# Altium schematic sheet ESP8285.SchDoc
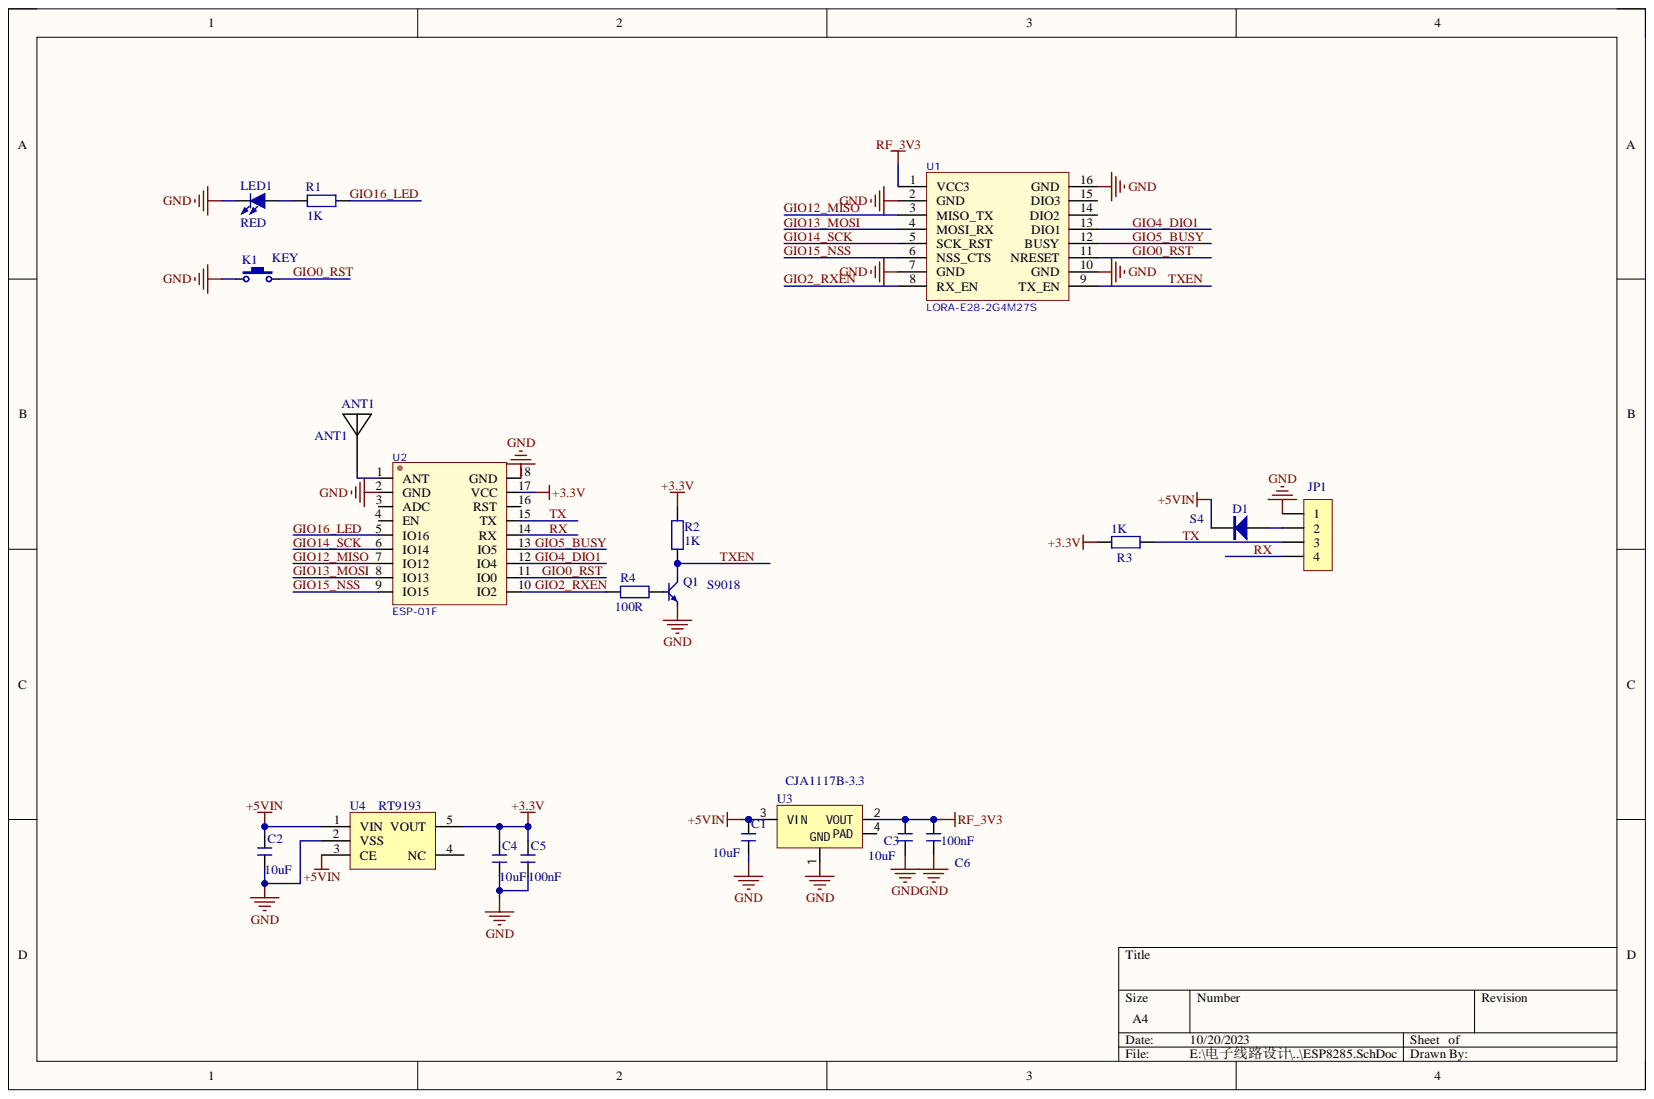
[diagram p0: ESP8285.SchDoc]

## Parts
ANT1  ANT1  [ANT_2.4G]
C1  10uF  [CAP_0603]
C2  10uF  [CAP_0603]
C3  10uF  [CAP_0603]
C4  10uF  [CAP_0603]
C5  100nF  [CAP_0603]
C6  100nF  [CAP_0603]
D1  S4  [SOD-123]
JP1  HDR-1X4  [HDR1X4_2.54_180]  (value not drawn)
K1  KEY  [SPARKFUN-JUMPERS_SMT-JUMPER_2_NC_TRACE_SILK]
LED1  RED  [LED_0603_RED]
Q1  S9018  [SOT23-3]
R1  1K  [RES_0603]
R2  1K  [RES_0603]
R3  1K  [RES_0603]
R4  100R  [RES_0603]
U1  LORA-E28-2G4M27S  [E28-2G4M2XS]
U2  ESP-01F  [ESP-01F]
U3  CJA1117B-3.3  [SOT89-3]
U4  RT9193  [SOT23-5]

## Nets
+3.3V: C4.2 C5.2 R2.2 R3.2 U2.17(VCC) U4.5(VOUT)
+5VIN: C1.2 C2.2 D1.2 U3.3(VIN) U4.1(VIN) U4.3(CE)
GND: C1.1 C2.1 C3.1 C4.1 C5.1 C6.1 JP1.1 K1.1 LED1.2 Q1.2 U1.2(GND) U1.7(GND) U1.10(GND) U1.16(GND) U2.2(GND) U2.18(GND) U3.1 U4.2(VSS)
RF_3V3: C3.2 C6.2 U1.1(VCC3) U3.2(VOUT)
GIO0_RST: K1.2 U1.11(NRESET) U2.11(IO0)
GIO2_RXEN: R4.2 U1.8(RX_EN) U2.10(IO2)
GIO4_DIO1: U1.13(DIO1) U2.12(IO4)
GIO5_BUSY: U1.12(BUSY) U2.13(IO5)
GIO12_MISO: U1.3(MISO_TX) U2.7(IO12)
GIO13_MOSI: U1.4(MOSI_RX) U2.8(IO13)
GIO14_SCK: U1.5(SCK_RST) U2.6(IO14)
GIO15_NSS: U1.6(NSS_CTS) U2.9(IO15)
GIO16_LED: R1.1 U2.5(IO16)
RX: JP1.4 U2.14(RX)
TX: JP1.3 R3.1 U2.15(TX)
TXEN: Q1.3 R2.1 U1.9(TX_EN)
NetANT1_1: ANT1.1 U2.1(ANT)
NetD1_1: D1.1 JP1.2
NetLED1_1: LED1.1 R1.2
NetQ1_1: Q1.1 R4.1

Not connected: U1 14(DIO2) 15(DIO3); U2 3(ADC) 4(EN) 16(RST); U3 4(GND_PAD); U4 4(NC)

## Library data of the parts
ANT1 = ANT1: PCB板载天线; 版权归属: 源创客; BOM名称: PCB板载天线; Component Kind: Standard; Library Name: 14.1 - 天线.SchLib; 学习资源: www.ooocke.com
C1-C6 = C: 无极性贴片电容; 版权归属: 源创客; BOM名称: 无极性贴片电容; Component Kind: Standard; Library Name: 3.1   - 电阻-电容-电感.SchLib; 学习资源: www.ooocke.com
D1 = 1N4007: 整流二极管; 版权归属: 源创客; BOM名称: 整流二极管; Component Kind: Standard; Library Name: 8.1   - 二极管-整流桥.SchLib; 学习资源: www.ooocke.com
JP1 = Header 4: 4P接插件; 版权归属: 源创客; BOM名称: 4P接插件; Component Kind: Standard; Library Name: 4.5   - 接插件-脚距2.54.SchLib; 学习资源: www.ooocke.com
K1 = ButtonTACT_180_3x4x2: Button; value: 3x4mm; Component Kind: Standard; Library Name: SCH_Switch.SchLib; type: TACT 2P
LED1 = LED-SMD: 贴片LED; 版权归属: 源创客; BOM名称: 贴片LED; Component Kind: Standard; Library Name: 9.1   - LED.SchLib; 学习资源: www.ooocke.com
Q1 = BJT_NPN_T: Transistor; Note: NPN; Type: SOT32-3; Component Kind: Standard; Library Name: SCH_Active.SchLib; Value: S9018
R1-R4 = R: 贴片电阻; 版权归属: 源创客; BOM名称: 贴片电阻; Component Kind: Standard; Library Name: 3.1   - 电阻-电容-电感.SchLib; 学习资源: www.ooocke.com
U3 = LDO1117: Power; Component Kind: Standard; Library Name: SCH_Power.SchLib; Type: SOT223-3
U4 = ME6211: Component Kind: Standard; Library Name: MINI.SCHLIB
U1 = LORA-E28-2G4M27S: Component Kind: Standard; Library Name: Schlib.SchLib
U2 = ESP-01F: Component Kind: Standard; Library Name: Schlib.SchLib
